# Altium schematic sheet B-3009.SchDoc
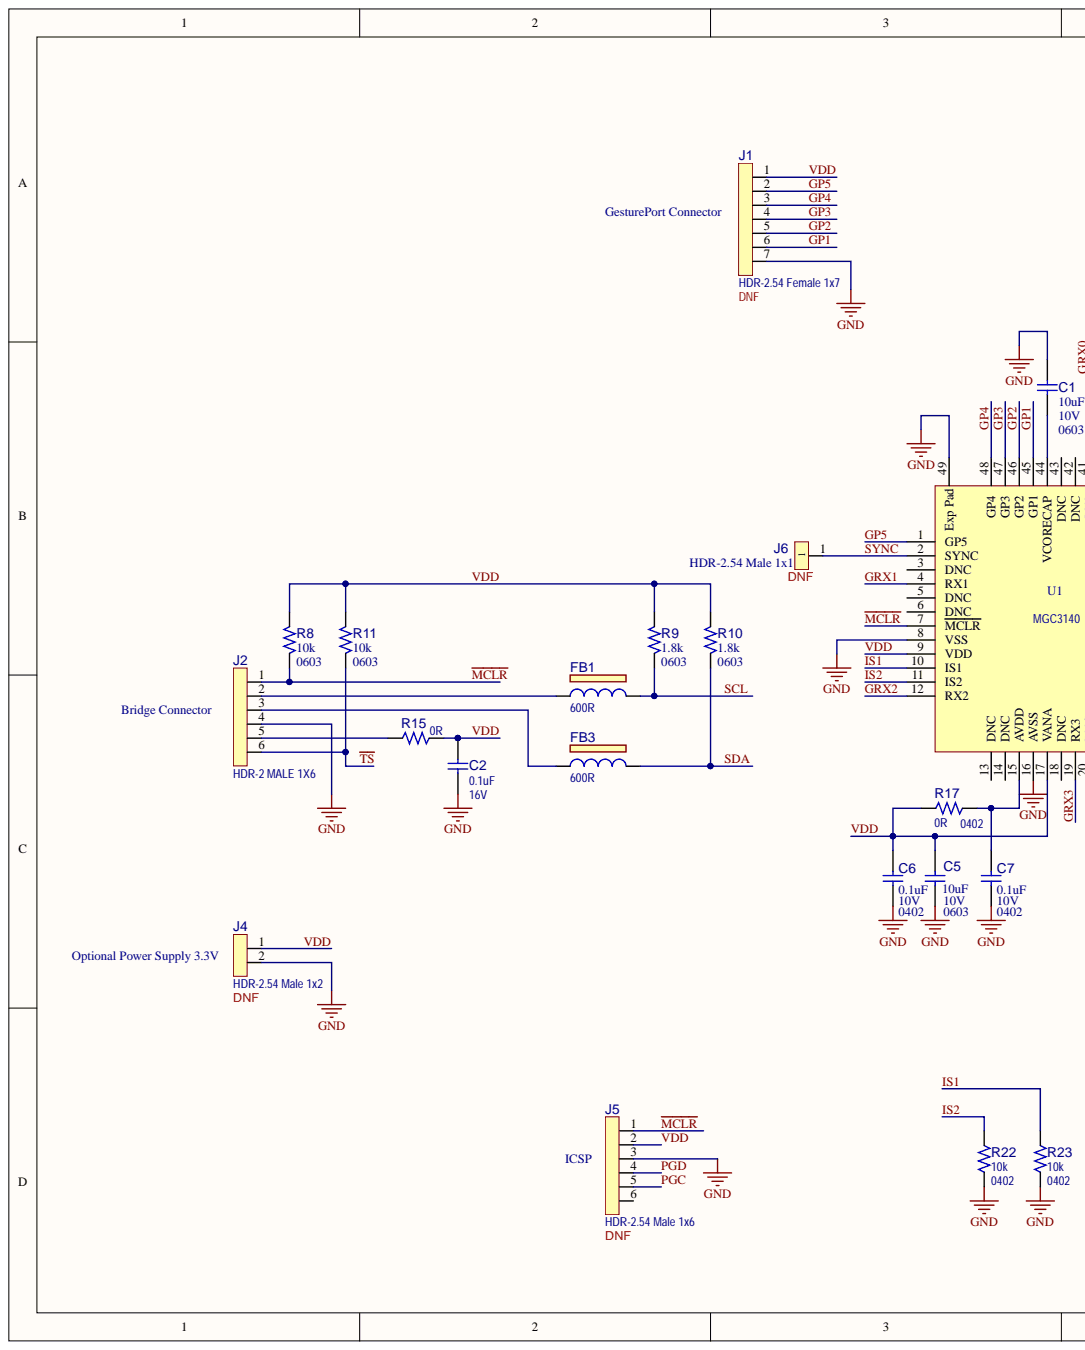
[diagram p0: B-3009.SchDoc, left]
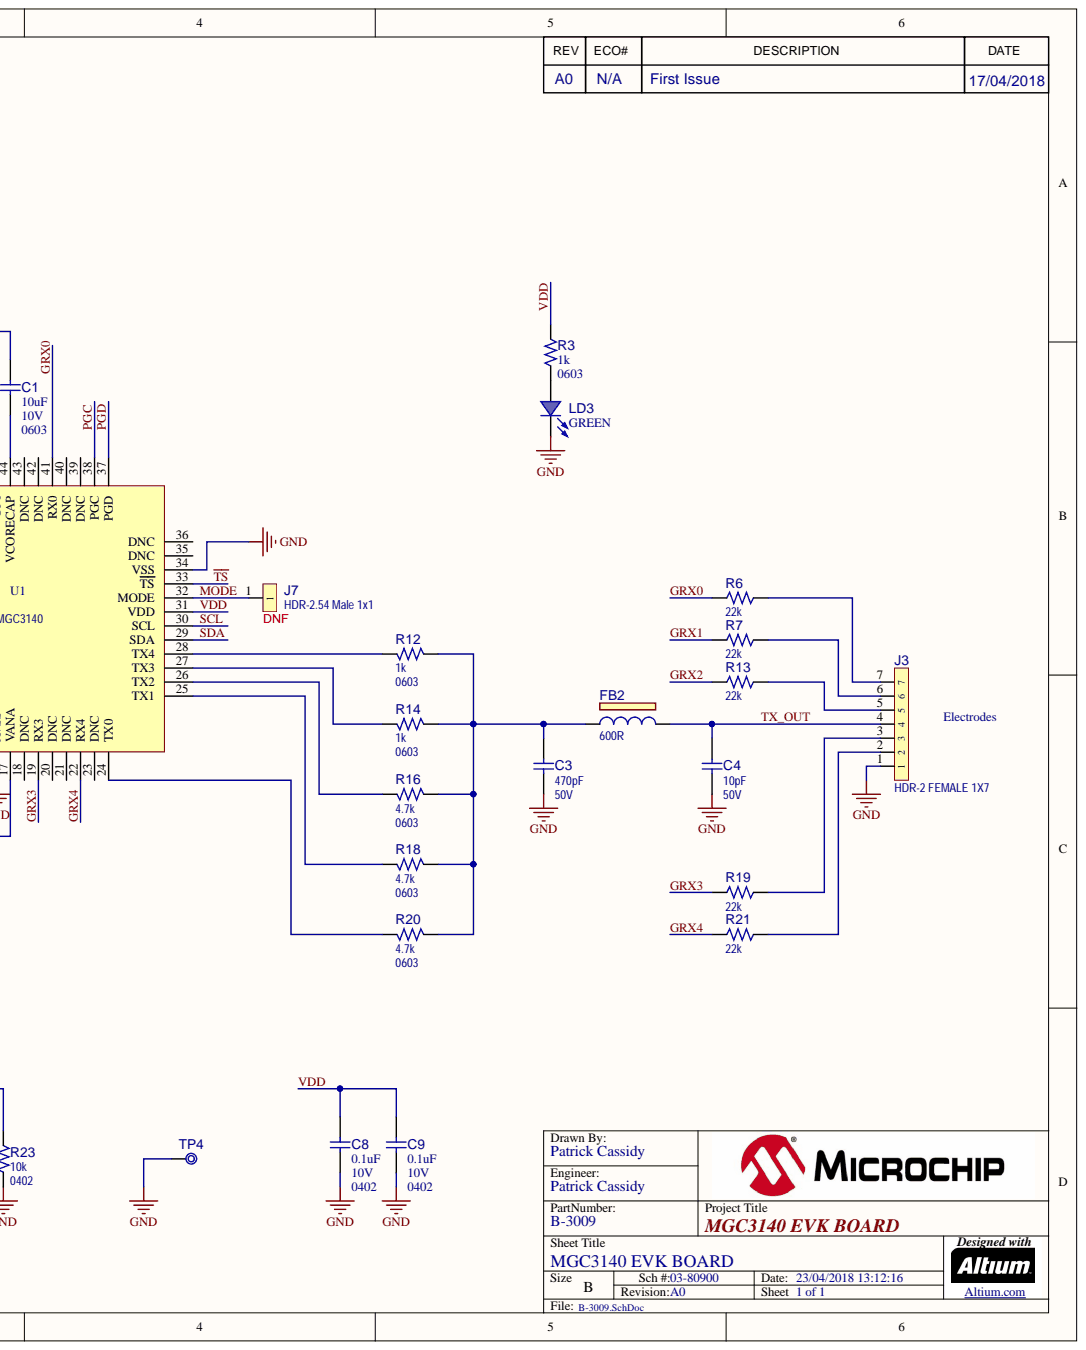
[diagram p1: B-3009.SchDoc, right]

## Parts
C1  value 10uF  Package: 0603  Voltage: 10V  [CAP_C_0603]  (hidden comment: CAP CER 10uF 10V 20% X5R SMD 0603)
C2  value 0.1uF  Voltage: 16V  [CAP_C_0603]  (hidden comment: Capacitor NP)
C3  value 470pF  Voltage: 50V  [CAP_C_0603]  (hidden comment: Capacitor NP)
C4  value 10pF  Voltage: 50V  [CAP_C_0603]  (hidden comment: Capacitor NP)
C5  value 10uF  Package: 0603  Voltage: 10V  [CAP_C_0603]  (hidden comment: CAP CER 10uF 10V 20% X5R SMD 0603)
C6  value 0.1uF  Package: 0402  Voltage: 10V  [CAP_C_0402]  (hidden comment: CAP CER 0.1uF 10V 10% X7R SMD 0402)
C7  value 0.1uF  Package: 0402  Voltage: 10V  [CAP_C_0402]  (hidden comment: CAP CER 0.1uF 10V 10% X7R SMD 0402)
C8  value 0.1uF  Package: 0402  Voltage: 10V  [CAP_C_0402]  (hidden comment: CAP CER 0.1uF 10V 10% X7R SMD 0402)
C9  value 0.1uF  Package: 0402  Voltage: 10V  [CAP_C_0402]  (hidden comment: CAP CER 0.1uF 10V 10% X7R SMD 0402)
FB1  value 600R  [IND_C_0603]  (hidden comment: Ferrite Bead)
FB2  value 600R  [IND_C_0603]  (hidden comment: Ferrite Bead)
FB3  value 600R  [IND_C_0603]  (hidden comment: Ferrite Bead)
J1  value HDR-2.54 Female 1x7  Category: Connector  Populated: DNF  [HDR_F_2.54_1X7]  (hidden comment: Header 1x7)
J2  value HDR-2 MALE 1X6  Category: Connector  [HDR_M_2_1X6_SMD_RA]  (hidden comment: CON HDR-2 Male 1x6 Tin 4MH SMD R/A)
J3  value HDR-2 FEMALE 1X7  [HDR_F_2_1X7_SMD_RA]  (hidden comment: CON HDR-2 Female 1x7 Tin SMD R/A)
J4  value HDR-2.54 Male 1x2  Populated: DNF  [HDR_M_2.54_1x2]  (hidden comment: Header 1x2)
J5  value HDR-2.54 Male 1x6  Populated: DNF  [HDR_M_2.54_1x6]  (hidden comment: Header 1x6)
J6  value HDR-2.54 Male 1x1  Populated: DNF  [HDR_M_2.54_1x1]  (hidden comment: Header 1x1)
J7  value HDR-2.54 Male 1x1  Populated: DNF  [HDR_M_2.54_1x1]  (hidden comment: Header 1x1)
LD3  value GREEN  Package: 0603  [LED_0603_GREEN]  (hidden comment: LTST-C190GKT)
R3  value 1k  Package: 0603  [RES_C_0603]  (hidden comment: RES TKF 1k 1% 1/10W SMD 0603)
R6  value 22k  [RES_C_0603]  (hidden comment: Resistor)
R7  value 22k  [RES_C_0603]  (hidden comment: Resistor)
R8  value 10k  Package: 0603  [RES_C_0603]  (hidden comment: RES TF 10k 1% 1/8W SMD 0603)
R9  value 1.8k  Package: 0603  [RES_C_0603]  (hidden comment: RES TKF 1.8k 1% 1/10W SMD 0603)
R10  value 1.8k  Package: 0603  [RES_C_0603]  (hidden comment: RES TKF 1.8k 1% 1/10W SMD 0603)
R11  value 10k  Package: 0603  [RES_C_0603]  (hidden comment: RES TF 10k 1% 1/8W SMD 0603)
R12  value 1k  Package: 0603  [RES_C_0603]  (hidden comment: Resistor)
R13  value 22k  [RES_C_0603]  (hidden comment: Resistor)
R14  value 1k  Package: 0603  [RES_C_0603]  (hidden comment: Resistor)
R15  value 0R  [RES_C_0603]  (hidden comment: Resistor)
R16  value 4.7k  Package: 0603  [RES_C_0603]  (hidden comment: Resistor)
R17  value 0R  Package: 0402  [RES_C_0402]  (hidden comment: Resistor)
R18  value 4.7k  Package: 0603  [RES_C_0603]  (hidden comment: Resistor)
R19  value 22k  [RES_C_0603]  (hidden comment: Resistor)
R20  value 4.7k  Package: 0603  [RES_C_0603]  (hidden comment: Resistor)
R21  value 22k  [RES_C_0603]  (hidden comment: Resistor)
R22  value 10k  Package: 0402  [RES_C_0402]  (hidden comment: Resistor)
R23  value 10k  Package: 0402  [RES_C_0402]  (hidden comment: Resistor)
TP4  Test Point No BOM  [TP_1.2x0.7]  (value not drawn)  (no BOM)
U1  MGC3140  [UQFN-48_6X6_EP4.45X4.45]

## Nets
GND: C1.2 C2.1 C3.1 C4.1 C5.1 C6.1 C7.1 C8.1 C9.1 J1.7 J2.4 J3.1 J4.2 J5.3 LD3.2 R22.1 R23.1 TP4.1 U1.8(VSS) U1.16(AVSS) U1.34(VSS) U1.49(Exp_Pad)
~{MCLR}: J2.1 J5.1 R8.1 U1.7(MCLR)
~{TS}: J2.6 R11.1 U1.33(TS)
GP1: J1.6 U1.45(GP1)
GP2: J1.5 U1.46(GP2)
GP3: J1.4 U1.47(GP3)
GP4: J1.3 U1.48(GP4)
GP5: J1.2 U1.1(GP5)
GRX0: R6.1 U1.41(RX0)
GRX1: R7.1 U1.4(RX1)
GRX2: R13.1 U1.12(RX2)
GRX3: R19.1 U1.19(RX3)
GRX4: R21.1 U1.22(RX4)
IS1: R23.2 U1.10(IS1)
IS2: R22.2 U1.11(IS2)
MODE: J7.1 U1.32(MODE)
PGC: J5.5 U1.38(PGC)
PGD: J5.4 U1.37(PGD)
SCL: FB1.2 R9.1 U1.30(SCL)
SDA: FB3.2 R10.1 U1.29(SDA)
SYNC: J6.1 U1.2(SYNC)
TX_OUT: C4.2 FB2.1 J3.4
VDD: C2.2 C5.2 C6.2 C8.2 C9.2 J1.1 J4.1 J5.2 R3.2 R8.2 R9.2 R10.2 R11.2 R15.2 R17.1 U1.9(VDD) U1.17(VANA) U1.31(VDD)
NetC1_1: C1.1 U1.44(VCORECAP)
NetC3_2: C3.2 FB2.2 R12.1 R14.1 R16.1 R18.1 R20.1
NetC7_2: C7.2 R17.2 U1.15(AVDD)
NetFB1_1: FB1.1 J2.2
NetFB3_1: FB3.1 J2.3
NetJ2_5: J2.5 R15.1
NetJ3_2: J3.2 R21.2
NetJ3_3: J3.3 R19.2
NetJ3_5: J3.5 R13.2
NetJ3_6: J3.6 R7.2
NetJ3_7: J3.7 R6.2
NetLD3_1: LD3.1 R3.1
NetR12_2: R12.2 U1.28(TX4)
NetR14_2: R14.2 U1.27(TX3)
NetR16_2: R16.2 U1.26(TX2)
NetR18_2: R18.2 U1.25(TX1)
NetR20_2: R20.2 U1.24(TX0)

Not connected: J5 6; U1 3(DNC) 5(DNC) 6(DNC) 13(DNC) 14(DNC) 18(DNC) 20(DNC) 21(DNC) 23(DNC) 35(DNC) 36(DNC) 39(DNC) 40(DNC) 42(DNC) 43(DNC)

## Library data of the parts
C1 C5 = CAP0542: Capacitor; Manufacturer 1: Panasonic; Manufacturer Part Number 1: ECJ-1VB1A106M; Dev Tools Status: Production; Populated: Yes; Type: Ceramic; Category: Capacitor; Component Kind: Standard; ComponentLink1Description: Datasheet; ComponentLink1URL: https://chn-vm-apps.microchip.com/mchprepo/parts/Datasheets/ECJ__General_.pdf; Database Table Name: Capacitor; Description_: CAP CER 10uF 10V 20% X5R SMD 0603; Library Name: MCHP-CDB-Offline.DbLib; Manufacturer 2: TDK Corporation; Manufacturer Part Number 2: C1608X5R1A106M; MCHP-ID: CAP0542; Operating Temperature: -55C to +85C; Quantity Override: 1; Revision: 2; Status: MCL Design; Supplier 1: Digi-Key; Supplier 2: Digi-Key; Supplier Part Number 1: PCC2479CT-ND; Supplier Part Number 2: 445-6853-1-ND; Temperature Coeffcient: X5R; Tolerance: 20%
C2 = CAP0011: Capacitor; Manufacturer 1: AVX; Manufacturer Part Number 1: 0603YC104KAT2A; Description_: CAP CER 0.1uF 16V 10% X7R SMD 0603; Dev Tools Status: Production; Populated: Yes; Temperature Coeffcient: X7R; Tolerance: 10%; Type: Ceramic; Category: Capacitor; Component Kind: Standard; ComponentLink1Description: Datasheet; ComponentLink1URL: https://chn-vm-apps.microchip.com/mchprepo/parts/Datasheets/nmc2.pdf; Database Table Name: Capacitor; Library Name: MCHP-CDB-Offline.DbLib; Manufacturer 2: Murata; Manufacturer 3: Panasonic; Manufacturer 4: Taiyo Yuden; Manufacturer 5: Yageo; Manufacturer 6: NIC Components; Manufacturer Part Number 2: GRM188R71C104KA01D; Manufacturer Part Number 3: ECJ-1VB1C104K; Manufacturer Part Number 4: EMK107B7104KA-T; Manufacturer Part Number 5: CC0603KRX7R7BB104; Manufacturer Part Number 6: NMC0603X7R104K16TRPF; MCHP-ID: CAP0011; Operating Temperature: -55C to +125C; Package: 0603; Quantity Override: 1; Revision: 3; Status: MCL Design; Supplier 1: Digi-Key; Supplier 2: Digi-Key; Supplier 3: Digi-Key; Supplier 4: Digi-Key; Supplier 5: Newark; Supplier 6: Digi-Key; Supplier 7: NIC Components; Supplier Part Number 1: 478-1239-1-ND; Supplier Part Number 2: 490-1532-1-ND; Supplier Part Number 3: PCC1762CT-ND; Supplier Part Number 4: 587-1240-2-ND; Supplier Part Number 5: 86H5248; Supplier Part Number 6: 311-1088-1-ND; Supplier Part Number 7: NMC0603X7R104K16TRPF; Symbol: Capacitor NP
C3 = CAP0408: Capacitor; Manufacturer 1: Johanson Dielectrics; Manufacturer Part Number 1: 500R14W471KV4T; Description_: CAP CER 470pF 50V 10% X7R SMD 0603; Dev Tools Status: Production; Populated: Yes; Temperature Coeffcient: X7R; Tolerance: 10%; Type: Ceramic; Category: Capacitor; Component Kind: Standard; ComponentLink1Description: SVN Datasheet; ComponentLink1URL: https://chn-vm-apps.microchip.com/mchprepo/parts/Datasheets/JDI_Surface-Mount_2012-01.pdf; ComponentLink2Description: Local Datasheet; ComponentLink3Description: Open Symbol; ComponentLink4Description: Open Footprint; ComponentLink5Description: Open Footprint 2; ComponentLink6Description: Open Footprint 3; ComponentLink7Description: Open Footprint 4; Database Table Name: Capacitor; Library Name: MCHP-CDB.DbLib; Manufacturer 2: Murata; Manufacturer 3: Yageo; Manufacturer Part Number 2: GRM188R71H471KA01D; Manufacturer Part Number 3: CC0603KRX7R9BB471; MCHP-ID: CAP0408; Operating Temperature: -55C to +125C; Package: 0603; Quantity Override: 1; Revision: 1; Status: MCL Design; Supplier 1: Digi-Key; Supplier 2: Digi-Key; Supplier 3: Digi-Key; Supplier Part Number 1: 709-1164-1-ND; Supplier Part Number 2: 490-1489-1-ND; Supplier Part Number 3: 311-1078-2-ND; Symbol: Capacitor NP; ComponentLink2URL: C:\ALTIUM_WORK\00001_MCHP-CDB\Datasheets\JDI_Surface-Mount_2012-01.pdf; ComponentLink3URL: C:\ALTIUM_WORK\00001_MCHP-CDB\Library\LibraryRefs\Capacitor NP.SchLib; ComponentLink4URL: C:\ALTIUM_WORK\00001_MCHP-CDB\Library\Footprints\CAP_C_0603.PcbLib
C4 = CAP0142: Capacitor; Manufacturer 1: KEMET; Manufacturer Part Number 1: C0603C100J5GACTU; Description_: CAP CER 10pF 50V 5% NP0 SMD 0603; Dev Tools Status: Production; Populated: Yes; Temperature Coeffcient: NP0; Tolerance: 5%; Type: Ceramic; Category: Capacitor; Component Kind: Standard; ComponentLink1Description: SVN Datasheet; ComponentLink1URL: https://chn-vm-apps.microchip.com/mchprepo/parts/Datasheets/KEM_C1002_X7R_SMD.pdf; ComponentLink2Description: Local Datasheet; ComponentLink3Description: Open Symbol; ComponentLink4Description: Open Footprint; ComponentLink5Description: Open Footprint 2; ComponentLink6Description: Open Footprint 3; ComponentLink7Description: Open Footprint 4; Database Table Name: Capacitor; Library Name: MCHP-CDB.DbLib; Manufacturer 2: Murata; Manufacturer 3: TDK; Manufacturer 4: KEMET; Manufacturer Part Number 2: GRM1885C1H100JA01D; Manufacturer Part Number 3: C1608C0G1H100J; Manufacturer Part Number 4: C0603C100J5GAC; MCHP-ID: CAP0142; Operating Temperature: -55C to +125C; Package: 0603; Quantity Override: 1; Revision: 1; Status: MCL Design; Supplier 1: Digi-Key; Supplier 2: Digi-Key; Supplier 3: Digi-Key; Supplier 4: Digi-Key; Supplier 5: KEMET; Supplier Part Number 1: 399-1049-2-ND; Supplier Part Number 2: 490-1403-2-ND; Supplier Part Number 3: 490-1403-1-ND; Supplier Part Number 4: 445-6852-1-ND; Supplier Part Number 5: C0603C100J5GAC; Symbol: Capacitor NP; ComponentLink2URL: C:\ALTIUM_WORK\00001_MCHP-CDB\Datasheets\KEM_C1002_X7R_SMD.pdf; ComponentLink3URL: C:\ALTIUM_WORK\00001_MCHP-CDB\Library\LibraryRefs\Capacitor NP.SchLib; ComponentLink4URL: C:\ALTIUM_WORK\00001_MCHP-CDB\Library\Footprints\CAP_C_0603.PcbLib
C6-C9 = CAP1238: Capacitor; Manufacturer 1: KEMET; Manufacturer Part Number 1: C0402C104K8RACTU; Dev Tools Status: Production; Owner: Tad Ahlvers; Populated: YES; Type: Ceramic; Category: Capacitor; Component Kind: Standard; ComponentLink1Description: Datasheet; ComponentLink1URL: https://chn-vm-apps.microchip.com/mchprepo/parts/Datasheets/KEM_C1002_X7R_SMD.pdf; Database Table Name: Capacitor; Description_: CAP CER 0.1uF 10V 10% X7R SMD 0402; Library Name: MCHP-CDB-Offline.DbLib; Manufacturer 2: Samsung; Manufacturer 3: Murata Electronics; Manufacturer Part Number 2: CL05B104KP5NNNC; Manufacturer Part Number 3: GRM155R71A104KA01D; MCHP-ID: CAP1238; Operating Temperature: -55C to +125C; Quantity Override: 1; Revision: 1; Status: MCL Design; Supplier 1: Digi-Key; Supplier 2: Digi-Key; Supplier 3: Mouser; Supplier Part Number 1: 399-3520-2-ND; Supplier Part Number 2: 1276-1002-2-ND; Supplier Part Number 3: 81-GRM155R71A104KA1D; Temperature Coeffcient: X7R; Tolerance: 10%
FB1 FB3 = FB0002: Manufacturer 1: Laird Technologies; Manufacturer Part Number 1: HZ0603C601R-00; Database Table Name: Inductor; DCR: 0.45R; Description_: FERRITE 600R@100MHz 300mA SMD 0603; Dev Tools Status: Production; Populated: Yes; Saturation Current: 300mA; Category: Inductor; Component Kind: Standard; ComponentLink1Description: Datasheet; ComponentLink1URL: https://chn-vm-apps.microchip.com/mchprepo/parts/Datasheets/HZ.pdf; Library Name: MCHP-CDB-Offline.DbLib; Manufacturer 2: Murata; Manufacturer 3: Laird Technologies; Manufacturer Part Number 2: BLM18AG601SN1D; Manufacturer Part Number 3: HZ0603C601R-10; MCHP-ID: FB0002; Operating Temperature: -55C to +125C; Package: 0603; Quantity Override: 1; Revision: 1; Status: MCL Design; Supplier 1: Digi-Key; Supplier 2: Digi-Key; Supplier 3: Laird Technologies; Supplier Part Number 1: 240-1143-2-ND; Supplier Part Number 2: 490-1014-2-ND; Supplier Part Number 3: HZ0603C601R-10; Symbol: Ferrite Bead; Type: Ferrite Bead
FB2 = FB0002: Manufacturer 1: Laird Technologies; Manufacturer Part Number 1: HZ0603C601R-00; Database Table Name: Inductor; DCR: 0.45R; Description_: FERRITE 600R@100MHz 300mA SMD 0603; Dev Tools Status: Production; Populated: YES; Saturation Current: 300mA; Category: Inductor; Component Kind: Standard; ComponentLink1Description: Datasheet; ComponentLink1URL: https://chn-vm-apps.microchip.com/mchprepo/parts/Datasheets/HZ.pdf; Library Name: MCHP-CDB-Offline.DbLib; Manufacturer 2: Murata; Manufacturer 3: Laird Technologies; Manufacturer Part Number 2: BLM18AG601SN1D; Manufacturer Part Number 3: HZ0603C601R-10; MCHP-ID: FB0002; Operating Temperature: -55C to +125C; Package: 0603; Quantity Override: 1; Revision: 1; Status: MCL Design; Supplier 1: Digi-Key; Supplier 2: Digi-Key; Supplier 3: Laird Technologies; Supplier Part Number 1: 240-1143-2-ND; Supplier Part Number 2: 490-1014-2-ND; Supplier Part Number 3: HZ0603C601R-10; Symbol: Ferrite Bead; Type: Ferrite Bead
J1 = CON1362: Manufacturer 1: Sullins Connector Solutions; Manufacturer Part Number 1: PPPC071LFBN-RC; Description_: CON HDR-2.54 Female 1x7 Gold TH; Dev Tools Status: Design; Owner: Jamus Griego; Component Kind: Standard; ComponentLink1Description: SVN Datasheet; ComponentLink1URL: https://chn-vm-apps.microchip.com/mchprepo/parts/Datasheets/78_P(N)PxCxxxLFBN-RC,_10492-G.pdf; ComponentLink2Description: Local Datasheet; ComponentLink3Description: Open Symbol; ComponentLink4Description: Open Footprint; ComponentLink5Description: Open Footprint 2; ComponentLink6Description: Open Footprint 3; ComponentLink7Description: Open Footprint 4; Database Table Name: Connector; Library Name: MCHP-CDB-Offline.DbLib; MCHP-ID: CON1362; Quantity Override: 1; Revision: A; Status: MCL Design; Supplier 1: Digi-Key; Supplier Part Number 1: S7040-ND; Symbol: Header 1x7; Type: Header 2.54mm; ComponentLink2URL: C:\ALTIUM_WORK\00001_MCHP-CDB\Datasheets\78_P(N)PxCxxxLFBN-RC,_10492-G.pdf; ComponentLink3URL: C:\ALTIUM_WORK\00001_MCHP-CDB\Library\LibraryRefs\Header 1x7.SchLib; ComponentLink4URL: C:\ALTIUM_WORK\00001_MCHP-CDB\Library\Footprints\HDR_F_2.54_1X7.PcbLib
J2 = CON1203: Manufacturer 1: Fischer Elektronik; Manufacturer Part Number 1: SLY 9 SMD 040 6 S; Current: 3A; Dev Tools Status: Production; Owner: Jairuz Agang-ang; Populated: YES; Voltage: 100 V DC; Component Kind: Standard; ComponentLink1Description: Datasheet; ComponentLink1URL: https://chn-vm-apps.microchip.com/mchprepo/parts/Datasheets/735324-da-01-de-STIFTLEISTE__SLY_9_SMD_040__20_Z.pdf; Database Table Name: Connector; Description_: CON HDR-2 Male 1x6 Tin 4MH SMD R/A; Library Name: MCHP-CDB-Offline.DbLib; MCHP-ID: CON1203; Quantity Override: 1; Revision: 1; Status: MCL Design; Supplier 1: Fischer Elektronik; Supplier Part Number 1: SLY 9 SMD 040 6 S; Type: Header 2mm
J3 = CON1204: Manufacturer 1: Fischer Elektronik; Manufacturer Part Number 1: BLY 6 SMD 7; Current: 2.5A; Database Table Name: Connector; Dev Tools Status: Production; Owner: Jairuz Agang-ang; Populated: YES; Voltage: 100 V DC; Category: Connector; Component Kind: Standard; ComponentLink1Description: Datasheet; ComponentLink1URL: https://chn-vm-apps.microchip.com/mchprepo/parts/Datasheets/735584-da-01-de-BUCHSENLEISTE__BLY_6_SMD__20.pdf; Description_: CON HDR-2 Female 1x7 Tin SMD R/A; Library Name: MCHP-CDB-Offline.DbLib; Manufacturer 2: DONGGUAN WCON HARDWARE ELECTRONICS CO., LTD.; Manufacturer Part Number 2: 3522-28107ZS0074A1; MCHP-ID: CON1204; Quantity Override: 1; Revision: 1; Status: MCL Design; Supplier 1: Fischer Elektronik; Supplier 2: DONGGUAN WCON HARDWARE ELECTRONICS CO., LTD.; Supplier Part Number 1: BLY 6 SMD 7; Supplier Part Number 2: 3522-28107ZS0074A1; Type: Header 2mm
J4 = CON1108: Manufacturer 1: FCI; Manufacturer Part Number 1: 77311-118-02LF; Database Table Name: Connector; Description_: CON HDR-2.54 Male 1x2 Gold 5.84MH TH VERT; Dev Tools Status: Production; Owner: Bogdan P (M91398); Category: Connector; Component Kind: Standard; ComponentLink1Description: Datasheet; ComponentLink1URL: https://chn-vm-apps.microchip.com/mchprepo/parts/Datasheets/77311.pdf; Library Name: MCHP-CDB-Offline.DbLib; Manufacturer 2: FCI; Manufacturer 3: FCI; Manufacturer 4: Samtec; Manufacturer 5: Sullins; Manufacturer 6: SPC Technology; Manufacturer 7: Samtec; Manufacturer 8: Nextron; Manufacturer 9: Samtec; Manufacturer Part Number 2: 68001-202HLF; Manufacturer Part Number 3: 68000-202HLF; Manufacturer Part Number 4: TSW-102-07-G-S; Manufacturer Part Number 5: PBC02SAAN; Manufacturer Part Number 6: SPC20481; Manufacturer Part Number 7: TSW-102-07-F-S; Manufacturer Part Number 8: R-211-0211-0021-000; Manufacturer Part Number 9: TSW-102-07-S-S; MCHP-ID: CON1108; Quantity Override: 1; Revision: 1; Status: MCL Design; Supplier 1: Digi-Key; Supplier 2: Digi-Key; Supplier 3: Digi-Key; Supplier 4: Digi-Key; Supplier 5: Digi-Key; Supplier 6: Newark; Supplier 7: Newark; Supplier 8: Raynen; Supplier 9: Samtec; Supplier Part Number 1: 609-4434-ND; Supplier Part Number 2: 609-3469-ND; Supplier Part Number 3: 609-3502-ND; Supplier Part Number 4: SAM1029-02-ND; Supplier Part Number 5: S1011E-02-ND; Supplier Part Number 6: 93K5734; Supplier Part Number 7: 79P3146; Supplier Part Number 8: R-211-0211-0021-000; Supplier Part Number 9: TSW-102-07-S-S; Symbol: Header 1x2; Type: Header 2.54mm
J5 = CON0438: Manufacturer 1: Sullins; Manufacturer Part Number 1: PEC06SAAN; Current: 3A; Database Table Name: Connector; Description_: CON HDR-2.54 Male 1x6 Tin 5.84MH TH VERT; Dev Tools Status: Production; Category: Connector; Component Kind: Standard; ComponentLink1Description: SVN Datasheet; ComponentLink1URL: https://chn-vm-apps.microchip.com/mchprepo/parts/Datasheets/97_Page78-79_HEADER_.100_2.54mm_MALE_BREAKAWAY.pdf; ComponentLink2Description: Local Datasheet; ComponentLink3Description: Open Symbol; ComponentLink4Description: Open Footprint; ComponentLink5Description: Open Footprint 2; ComponentLink6Description: Open Footprint 3; ComponentLink7Description: Open Footprint 4; Library Name: MCHP-CDB.DbLib; Manufacturer 2: Nextron; Manufacturer Part Number 2: R-211-0611-0021-400; MCHP-ID: CON0438; Quantity Override: 1; Revision: 1; Status: MCL Design; Supplier 1: Digi-Key; Supplier 2: Raynen; Supplier Part Number 1: S1012E-06-ND; Supplier Part Number 2: CONN00260B01M; Symbol: Header 1x6; Type: Header 2.54mm; ComponentLink2URL: C:\ALTIUM_WORK\00001_MCHP-CDB\Datasheets\97_Page78-79_HEADER_.100_2.54mm_MALE_BREAKAWAY.pdf; ComponentLink3URL: C:\ALTIUM_WORK\00001_MCHP-CDB\Library\LibraryRefs\Header 1x6.SchLib; ComponentLink4URL: C:\ALTIUM_WORK\00001_MCHP-CDB\Library\Footprints\HDR_M_2.54_1x6.PcbLib
J6 J7 = CON1102: CON HDR-2.54 Male 1x1 Gold 5.97MH TH VERT; Manufacturer 1: TE Connectivity; Manufacturer Part Number 1: 5-146280-1; Database Table Name: Connector; Description_: CON HDR-2.54 Male 1x1 Gold 5.84MH TH VERT; Dev Tools Status: Production; Owner: Bogdan P (M91398); Category: Connector; Component Kind: Standard; ComponentLink1Description: SVN Datasheet; ComponentLink1URL: https://chn-vm-apps.microchip.com/mchprepo/parts/Datasheets/ENG_CD_103239_H2.pdf; ComponentLink2Description: Local Datasheet; ComponentLink3Description: Open Symbol; ComponentLink4Description: Open Footprint; ComponentLink5Description: Open Footprint 2; ComponentLink6Description: Open Footprint 3; ComponentLink7Description: Open Footprint 4; Library Name: MCHP-CDB-Offline.DbLib; Manufacturer 2: Nextron; Manufacturer 3: TE Connectivity; Manufacturer Part Number 2: 211-0111-0021-400; Manufacturer Part Number 3: 5-146868-1; MCHP-ID: CON1102; Quantity Override: 1; Revision: 0; Status: MCL Design; Supplier 1: Digi-Key; Supplier 2: Raynen; Supplier 3: Digi-Key; Supplier Part Number 1: A107006-ND; Supplier Part Number 2: 211-0111-0021-400; Supplier Part Number 3: A105161CT-ND; Symbol: Header 1x1; Type: Header 2.54mm; ComponentLink2URL: C:\ALTIUM_WORK\00001_MCHP-CDB\Datasheets\ENG_CD_103239_H2.pdf; ComponentLink3URL: C:\ALTIUM_WORK\00001_MCHP-CDB\Library\LibraryRefs\Header 1x1.SchLib; ComponentLink4URL: C:\ALTIUM_WORK\00001_MCHP-CDB\Library\Footprints\HDR_M_2.54_1x1.PcbLib
  J7: Value: HDR-2.54 Male 1x1
LD3 = LED0113: LED; Manufacturer 1: Lite-On; Database Table Name: Diode; Description_: DIO LED GREEN 2.1V 30mA 6mcd Clear SMD 0603; Dev Tools Status: Production; Forward Current: 30mA; Populated: Yes; Power: 100mW; Reverse Current: 100uA; Reverse Voltage: 5V; Type: LED Single; Category: Diode; Component Kind: Standard; ComponentLink1Description: Datasheet; ComponentLink1URL: https://chn-vm-apps.microchip.com/mchprepo/parts/Datasheets/LTST-C190GKT.pdf; Library Name: MCHP-CDB-Offline.DbLib; Manufacturer Part Number 1: LTST-C190GKT; MCHP-ID: LED0113; Operating Temperature: -55C to +85C; Quantity Override: 1; Revision: 1; Status: MCL Design; Supplier 1: Digi-Key; Supplier Part Number 1: 160-1183-1-ND
R3 = RSMT0138: Resistor; Manufacturer 1: Panasonic; Manufacturer Part Number 1: ERJ-3EKF1001V; Dev Tools Status: Production; Populated: Yes; Temperature Coeffcient: 100ppm/C; Voltage: 75V; Category: Resistor; Component Kind: Standard; ComponentLink1Description: Datasheet; ComponentLink1URL: https://chn-vm-apps.microchip.com/mchprepo/parts/Datasheets/AOA0000CE2.pdf; Composition: TKF; Database Table Name: Resistor; Description_: RES TKF 1k 1% 1/10W SMD 0603; Library Name: MCHP-CDB-Offline.DbLib; Manufacturer 2: Yageo; Manufacturer 3: ROHM; Manufacturer 4: Stackpole Electronics Inc; Manufacturer 5: Stackpole Electronics Inc; Manufacturer 6: Vishay; Manufacturer 7: Stackpole Electronics Inc; Manufacturer 8: TE Connectivity; Manufacturer Part Number 2: RC0603FR-071KL; Manufacturer Part Number 3: MCR03EZPFX1001; Manufacturer Part Number 4: RMCF0603FT1K00; Manufacturer Part Number 5: RMCF 1/16 1K 1% R; Manufacturer Part Number 6: CRCW06031K00FKEA; Manufacturer Part Number 7: RMCF1/161.0K1%TR; Manufacturer Part Number 8: 1622866-1; MCHP-ID: RSMT0138; Operating Temperature: -55C to +155C; Power: 1/10W; Quantity Override: 1; Revision: 2; Status: MCL Design; Supplier 1: Digi-Key; Supplier 2: Digi-Key; Supplier 3: Digi-Key; Supplier 4: Digi-Key; Supplier 5: Digi-Key; Supplier 6: Digi-Key; Supplier 7: Newark; Supplier 8: Stackpole Electronics Inc; Supplier 9: Digi-Key; Supplier Part Number 1: P1.00KHCT-ND; Supplier Part Number 2: P1.00KHTR-ND; Supplier Part Number 3: 311-1.00KHRCT-ND; Supplier Part Number 4: RHM1.00KHCT-ND; Supplier Part Number 5: RMCF1/161KFRTR-ND; Supplier Part Number 6: RMCF0603FT1K00TR-ND; Supplier Part Number 7: 52K8015; Supplier Part Number 8: RMCF1/161.0K1%TR; Supplier Part Number 9: A106049CT-ND; Tolerance: 1%; Type: RES SMD
R6 R7 R13 R19 R21 = RES1145: Manufacturer 1: Panasonic; Manufacturer Part Number 1: ERJ-3GEYJ223V; Description_: RES TKF 22k 5% 1/10W SMD 0603; Dev Tools Status: Production; Owner: Bogdan P (M91398); Populated: Yes; Power: 1/10W; Temperature Coeffcient: 200ppm/C; Tolerance: 5%; Voltage: 75V; Category: Resistor; Component Kind: Standard; ComponentLink1Description: SVN Datasheet; ComponentLink1URL: https://chn-vm-apps.microchip.com/mchprepo/parts/Datasheets/AOA0000CE1.pdf; ComponentLink2Description: Local Datasheet; ComponentLink3Description: Open Symbol; ComponentLink4Description: Open Footprint; ComponentLink5Description: Open Footprint 2; ComponentLink6Description: Open Footprint 3; ComponentLink7Description: Open Footprint 4; Composition: TKF; Database Table Name: Resistor; Description: Resistor; Library Name: MCHP-CDB.DbLib; MCHP-ID: RES1145; Operating Temperature: -55C to +155C; Package: 0603; Quantity Override: 1; Revision: 0; Status: MCL Design; Supplier 1: Digi-Key; Supplier Part Number 1: P22KGCT-ND; Symbol: Resistor; Type: RES SMD; ComponentLink2URL: C:\ALTIUM_WORK\00001_MCHP-CDB\Datasheets\AOA0000CE1.pdf; ComponentLink3URL: C:\ALTIUM_WORK\00001_MCHP-CDB\Library\LibraryRefs\Resistor.SchLib; ComponentLink4URL: C:\ALTIUM_WORK\00001_MCHP-CDB\Library\Footprints\RES_C_0603.PcbLib
R8 R11 = RES1382: Resistor; Manufacturer 1: Vishay Beyschlag; Manufacturer Part Number 1: MCT06030C1002FP500; Circuit Type: Isolated; Dev Tools Status: Production; Owner: Jairuz Agang-ang; Populated: YES; Temperature Coeffcient: 50ppm/C; Voltage: 75V; Category: Resistor; Component Kind: Standard; ComponentLink1Description: Datasheet; ComponentLink1URL: https://chn-vm-apps.microchip.com/mchprepo/parts/Datasheets/mc_pro.pdf; Composition: TF; Database Table Name: Resistor; Description_: RES TF 10k 1% 1/8W SMD 0603; Library Name: MCHP-CDB-Offline.DbLib; MCHP-ID: RES1382; Operating Temperature: -55C to +125C; Power: 1/8W; Quantity Override: 1; Revision: 1; Status: MCL Design; Supplier 1: Digi-Key; Supplier Part Number 1: MCT0603-10.0K-CFTR-ND; Tolerance: 1%; Type: RES SMD
R9 R10 = RES1110: Resistor; Manufacturer 1: Panasonic; Manufacturer Part Number 1: ERJ-3EKF1801V; Dev Tools Status: Production; Owner: Bogdan P (M91398); Populated: Yes; Temperature Coeffcient: 100ppm/C; Voltage: 75V; Category: Resistor; Component Kind: Standard; ComponentLink1Description: Datasheet; ComponentLink1URL: https://chn-vm-apps.microchip.com/mchprepo/parts/Datasheets/AOA0000CE2.pdf; Composition: TKF; Database Table Name: Resistor; Description_: RES TKF 1.8k 1% 1/10W SMD 0603; Library Name: MCHP-CDB-Offline.DbLib; MCHP-ID: RES1110; Operating Temperature: -55C to +155C; Power: 1/10W; Quantity Override: 1; Revision: 0; Status: MCL Design; Supplier 1: Digi-Key; Supplier Part Number 1: P1.80KHCT-ND; Tolerance: 1%; Type: RES SMD
R12 R14 = RSMT0138: Manufacturer 1: Panasonic; Manufacturer Part Number 1: ERJ-3EKF1001V; Description_: RES TKF 1k 1% 1/10W SMD 0603; Dev Tools Status: Production; Populated: Yes; Power: 1/10W; Temperature Coeffcient: 100ppm/C; Tolerance: 1%; Voltage: 75V; Category: Resistor; Component Kind: Standard; ComponentLink1Description: SVN Datasheet; ComponentLink1URL: https://chn-vm-apps.microchip.com/mchprepo/parts/Datasheets/AOA0000CE2.pdf; ComponentLink2Description: Local Datasheet; ComponentLink3Description: Open Symbol; ComponentLink4Description: Open Footprint; ComponentLink5Description: Open Footprint 2; ComponentLink6Description: Open Footprint 3; ComponentLink7Description: Open Footprint 4; Composition: TKF; Database Table Name: Resistor; Description: Resistor; Library Name: MCHP-CDB.DbLib; Manufacturer 2: Yageo; Manufacturer 3: ROHM; Manufacturer 4: Stackpole Electronics Inc; Manufacturer 5: Stackpole Electronics Inc; Manufacturer 6: Vishay; Manufacturer 7: Stackpole Electronics Inc; Manufacturer 8: TE Connectivity; Manufacturer Part Number 2: RC0603FR-071KL; Manufacturer Part Number 3: MCR03EZPFX1001; Manufacturer Part Number 4: RMCF0603FT1K00; Manufacturer Part Number 5: RMCF 1/16 1K 1% R; Manufacturer Part Number 6: CRCW06031K00FKEA; Manufacturer Part Number 7: RMCF1/161.0K1%TR; Manufacturer Part Number 8: 1622866-1; MCHP-ID: RSMT0138; Operating Temperature: -55C to +155C; Quantity Override: 1; Revision: 2; Status: MCL Design; Supplier 1: Digi-Key; Supplier 2: Digi-Key; Supplier 3: Digi-Key; Supplier 4: Digi-Key; Supplier 5: Digi-Key; Supplier 6: Digi-Key; Supplier 7: Newark; Supplier 8: Stackpole Electronics Inc; Supplier 9: Digi-Key; Supplier Part Number 1: P1.00KHCT-ND; Supplier Part Number 2: P1.00KHTR-ND; Supplier Part Number 3: 311-1.00KHRCT-ND; Supplier Part Number 4: RHM1.00KHCT-ND; Supplier Part Number 5: RMCF1/161KFRTR-ND; Supplier Part Number 6: RMCF0603FT1K00TR-ND; Supplier Part Number 7: 52K8015; Supplier Part Number 8: RMCF1/161.0K1%TR; Supplier Part Number 9: A106049CT-ND; Symbol: Resistor; Type: RES SMD; ComponentLink2URL: C:\ALTIUM_WORK\00001_MCHP-CDB\Datasheets\AOA0000CE2.pdf; ComponentLink3URL: C:\ALTIUM_WORK\00001_MCHP-CDB\Library\LibraryRefs\Resistor.SchLib; ComponentLink4URL: C:\ALTIUM_WORK\00001_MCHP-CDB\Library\Footprints\RES_C_0603.PcbLib
R15 = RSMT0001: Manufacturer 1: Panasonic; Manufacturer Part Number 1: ERJ-3GSY0R00V; Description_: RES TKF 0R 1/10W SMD 0603; Dev Tools Status: Production; Populated: Yes; Power: 1/10W; Category: Resistor; Component Kind: Standard; ComponentLink1Description: SVN Datasheet; ComponentLink1URL: https://chn-vm-apps.microchip.com/mchprepo/parts/Datasheets/nrc.pdf; ComponentLink2Description: Local Datasheet; ComponentLink3Description: Open Symbol; ComponentLink4Description: Open Footprint; ComponentLink5Description: Open Footprint 2; ComponentLink6Description: Open Footprint 3; ComponentLink7Description: Open Footprint 4; Composition: TKF; Database Table Name: Resistor; Description: Resistor; Library Name: MCHP-CDB.DbLib; Manufacturer 2: Panasonic; Manufacturer 3: ROHM; Manufacturer 4: Stackpole Electronics Inc; Manufacturer 5: Stackpole Electronics Inc; Manufacturer 6: Vishay; Manufacturer 7: Yageo; Manufacturer 8: KOA Speer; Manufacturer 9: NIC Components; Manufacturer Part Number 2: ERJ-3GEY0R00V; Manufacturer Part Number 3: MCR03EZPJ000; Manufacturer Part Number 4: RMCF0603ZT0R00; Manufacturer Part Number 5: RMCF 1/16 0 R; Manufacturer Part Number 6: CRCW06030000Z0EA; Manufacturer Part Number 7: RC0603JR-070RL; Manufacturer Part Number 8: RK73Z1JTTP; Manufacturer Part Number 9: NRC06Z0TRF; MCHP-ID: RSMT0001; Operating Temperature: -55C to +155C; Package: 0603; Quantity Override: 1; Revision: 2; Status: MCL Design; Supplier 1: Digi-Key; Supplier 2: Digi-Key; Supplier 3: Digi-Key; Supplier 4: Digi-Key; Supplier 5: Digi-Key; Supplier 6: Digi-Key; Supplier 7: Digi-Key; Supplier 8: KOA Speer; Supplier 9: NIC Components; Supplier Part Number 1: P0.0GTR-ND; Supplier Part Number 2: RHM0.0GCT-ND; Supplier Part Number 3: RMCF0603ZT0R00TR-ND; Supplier Part Number 4: RMCF0603ZT0R00CT-ND; Supplier Part Number 5: RMCF1/160RTR-ND; Supplier Part Number 6: 541-0.0GCT-ND; Supplier Part Number 7: 311-0.0GRCT-ND; Supplier Part Number 8: RK73Z1JTTP; Supplier Part Number 9: NRC06Z0TRF; Symbol: Resistor; Type: RES SMD; ComponentLink2URL: C:\ALTIUM_WORK\00001_MCHP-CDB\Datasheets\nrc.pdf; ComponentLink3URL: C:\ALTIUM_WORK\00001_MCHP-CDB\Library\LibraryRefs\Resistor.SchLib; ComponentLink4URL: C:\ALTIUM_WORK\00001_MCHP-CDB\Library\Footprints\RES_C_0603.PcbLib
R16 R18 R20 = RSMT0628: Manufacturer 1: Panasonic; Manufacturer Part Number 1: ERJ-3EKF4701V; Description_: RES TKF 4.7k 1% 1/10W SMD 0603; Dev Tools Status: Production; Populated: Yes; Power: 1/10W; Temperature Coeffcient: 100ppm/C; Tolerance: 1%; Voltage: 75V; Category: Resistor; Component Kind: Standard; ComponentLink1Description: SVN Datasheet; ComponentLink1URL: https://chn-vm-apps.microchip.com/mchprepo/parts/Datasheets/AOA0000CE2.pdf; ComponentLink2Description: Local Datasheet; ComponentLink3Description: Open Symbol; ComponentLink4Description: Open Footprint; ComponentLink5Description: Open Footprint 2; ComponentLink6Description: Open Footprint 3; ComponentLink7Description: Open Footprint 4; Composition: TKF; Database Table Name: Resistor; Description: Resistor; Library Name: MCHP-CDB.DbLib; MCHP-ID: RSMT0628; Operating Temperature: -55C to +155C; Quantity Override: 1; Revision: 1; Status: MCL Design; Supplier 1: Digi-Key; Supplier Part Number 1: P4.70KHCT-ND; Symbol: Resistor; Type: RES SMD; ComponentLink2URL: C:\ALTIUM_WORK\00001_MCHP-CDB\Datasheets\AOA0000CE2.pdf; ComponentLink3URL: C:\ALTIUM_WORK\00001_MCHP-CDB\Library\LibraryRefs\Resistor.SchLib; ComponentLink4URL: C:\ALTIUM_WORK\00001_MCHP-CDB\Library\Footprints\RES_C_0603.PcbLib
R17 = RSMT0730: Manufacturer 1: Panasonic; Manufacturer Part Number 1: ERJ-2GE0R00X; Description_: RES TKF 0R SMD 0402; Dev Tools Status: Production; Populated: Yes; Power: 1/10W; Category: Resistor; Component Kind: Standard; ComponentLink1Description: Datasheet; ComponentLink1URL: https://chn-vm-apps.microchip.com/mchprepo/parts/Datasheets/AOA0000CE1.pdf; Composition: TKF; Database Table Name: Resistor; Description: Resistor; Library Name: MCHP-CDB-Offline.DbLib; Manufacturer 2: Vishay; Manufacturer Part Number 2: CRCW04020000Z0ED; MCHP-ID: RSMT0730; Operating Temperature: -55C to +155C; Quantity Override: 1; Revision: 2; Status: MCL Design; Supplier 1: Digi-Key; Supplier 2: Digi-Key; Supplier Part Number 1: P0.0JCT-ND; Supplier Part Number 2: 541-0.0JTR-ND; Symbol: Resistor; Type: RES SMD
R22 R23 = RSMT0439: Manufacturer 1: Vishay; Manufacturer Part Number 1: CRCW040210K0JNED; Description_: RES TKF 10k 5% 1/16W SMD 0402; Dev Tools Status: Production; Populated: Yes; Power: 1/16W; Temperature Coeffcient: 100ppm/C; Tolerance: 5%; Voltage: 50V; Category: Resistor; Component Kind: Standard; ComponentLink1Description: Datasheet; ComponentLink1URL: https://chn-vm-apps.microchip.com/mchprepo/parts/Datasheets/PYu-RC0402_51_RoHS_L_6.pdf; Composition: TKF; Database Table Name: Resistor; Description: Resistor; Library Name: MCHP-CDB-Offline.DbLib; Manufacturer 2: Yageo; Manufacturer Part Number 2: RC0402JR-0710KL; MCHP-ID: RSMT0439; Operating Temperature: -55C to +155C; Quantity Override: 1; Revision: 1; Status: MCL Design; Supplier 1: Digi-Key; Supplier 2: Digi-Key; Supplier Part Number 1: 541-10KJCT-ND; Supplier Part Number 2: 311-10KJRCT-ND; Symbol: Resistor; Type: RES SMD
TP4 = CON1128: Test Point; Database Table Name: Connector; Description_: CON TP PAD PCB 1.2x0.7 TH; Dev Tools Status: Production; Owner: Bogdan P (M91398); Populated: Yes; Category: Connector; ComponentLink1Description: Datasheet; Library Name: MCHP-CDB-Offline.DbLib; MCHP-ID: CON1128; Quantity Override: 1; Revision: 0; Status: MCL Design; Type: Test Point
U1 = Component_1_1: MGC3140 ES 48L; Manufacturer 1: Microchip Technology; Comment_1: GestIC Controller; Description_: GestIC MGC3140 UQFN-48; Component Kind: Standard; Library Name: MGC3140 Unit_V2_newMGC3140lib.SCHLIB; Value: MGC3140
